# Altium schematic sheet Arduino-Pro-Mini.SchDoc(Arduino-Pro-Mini)
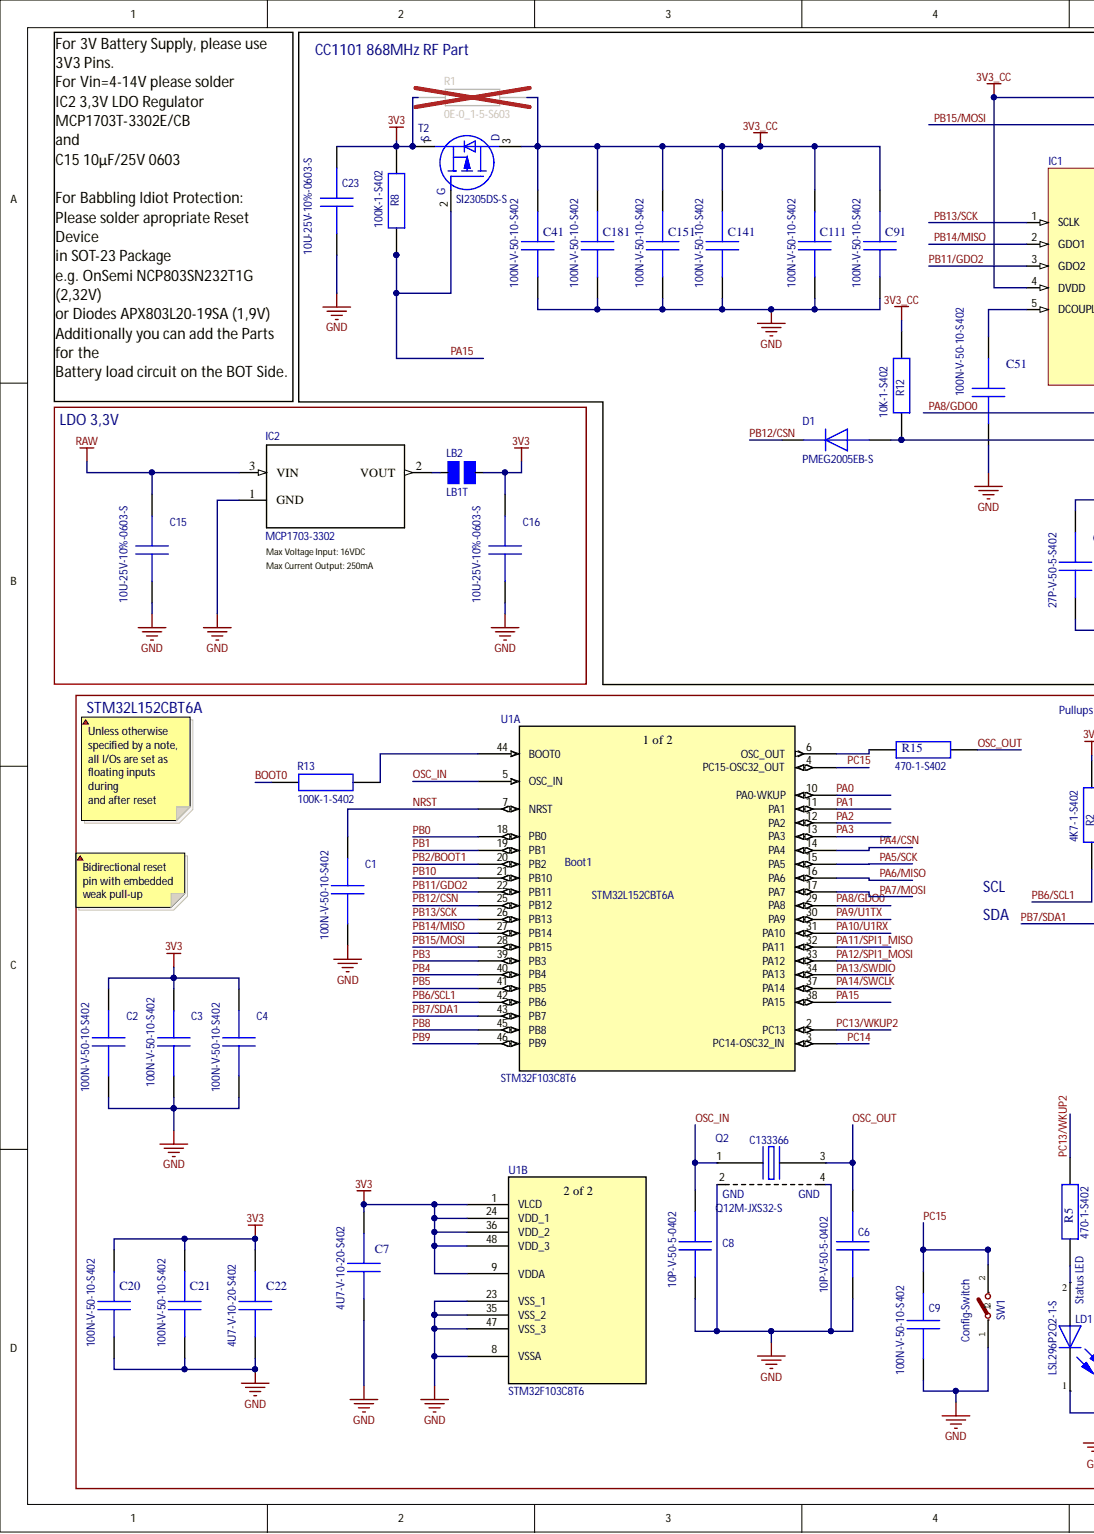
[diagram p0: Arduino-Pro-Mini.SchDoc, left]
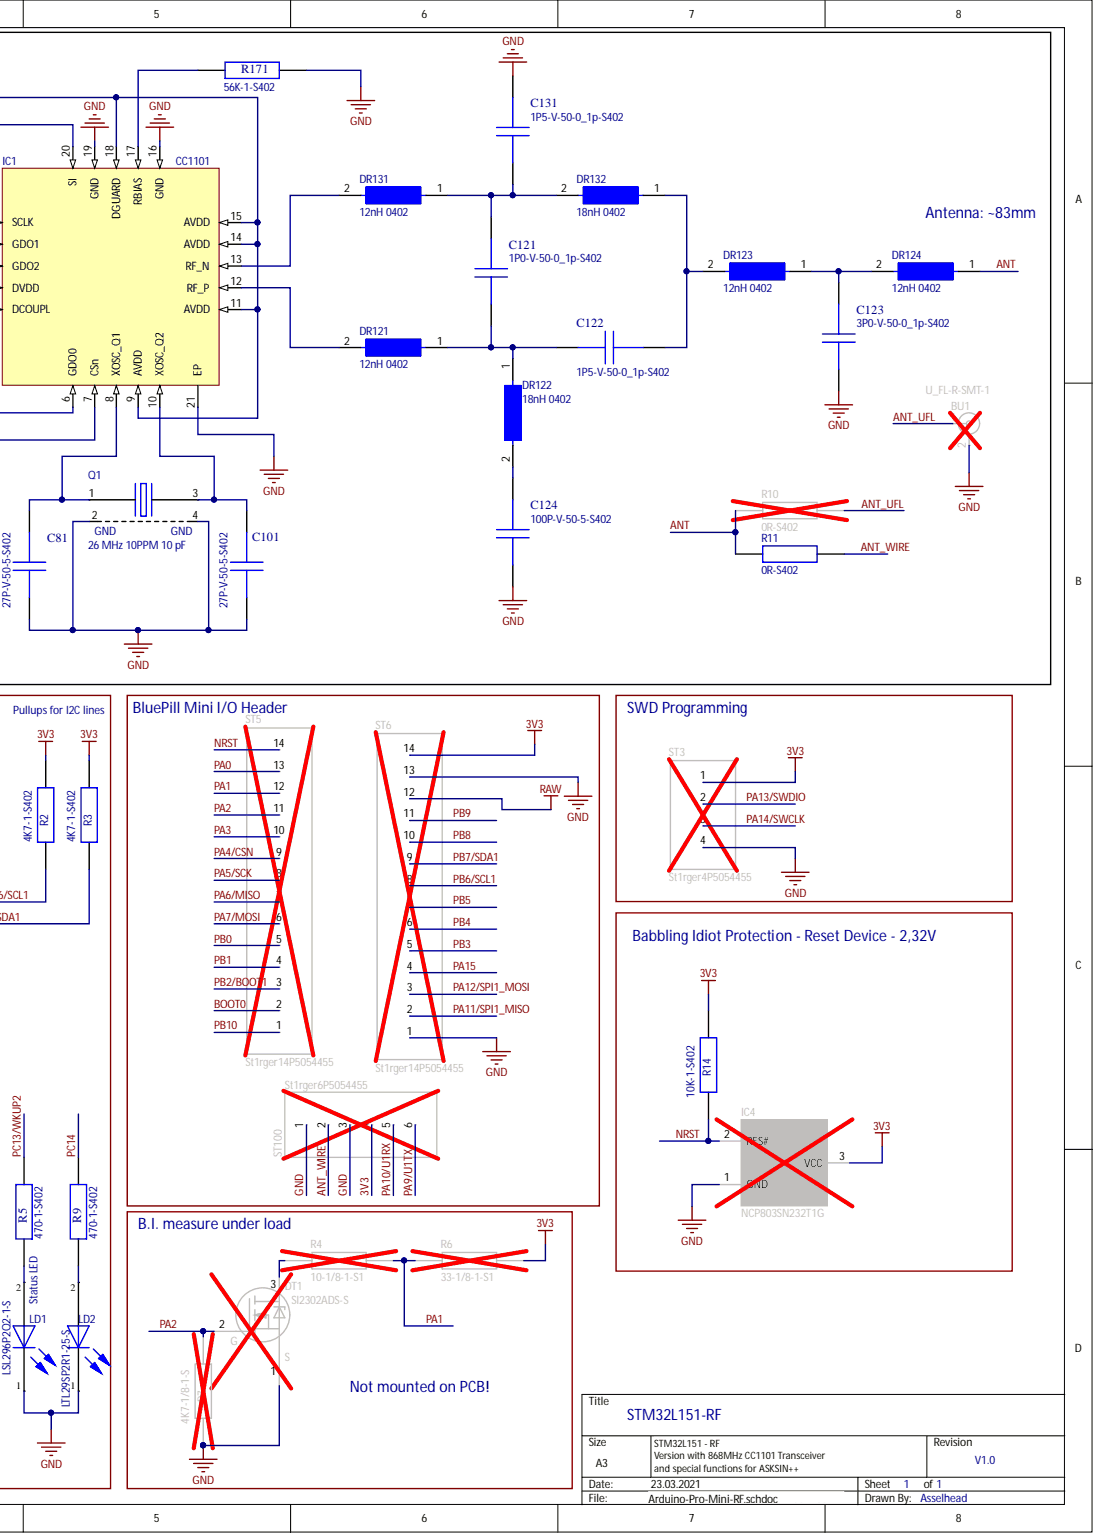
[diagram p1: Arduino-Pro-Mini.SchDoc, right]

## Parts
BU1  U_FL-R-SMT-1  [Foot_U_FL-R-SMT-1]
C1  100N-V-50-10-S402  [Foot 0402_Capacitor_Master]
C2  100N-V-50-10-S402  [Foot 0402_Capacitor_Master]
C3  100N-V-50-10-S402  [Foot 0402_Capacitor_Master]
C4  100N-V-50-10-S402  [Foot 0402_Capacitor_Master]
C6  10P-V-50-5-0402  [Foot 0402_Capacitor_Master]
C7  4U7-V-10-20-S402  [Foot 0402_Capacitor_Master]
C8  10P-V-50-5-0402  [Foot 0402_Capacitor_Master]
C9  100N-V-50-10-S402  [Foot 0402_Capacitor_Master]
C15  10U-25V-10%-0603-S  [Capacitor 0603_1.1mm]
C16  10U-25V-10%-0603-S  [Capacitor 0603_1.1mm]
C20  100N-V-50-10-S402  [Foot 0402_Capacitor_Master]
C21  100N-V-50-10-S402  [Foot 0402_Capacitor_Master]
C22  4U7-V-10-20-S402  [Foot 0402_Capacitor_Master]
C23  10U-25V-10%-0603-S  [Capacitor 0603_1.1mm]
C41  100N-V-50-10-S402  [Foot 0402_Capacitor_Master]
C51  100N-V-50-10-S402  [Foot 0402_Capacitor_Master]
C81  27P-V-50-5-S402  [Foot 0402_Capacitor_Master]
C91  100N-V-50-10-S402  [Foot 0402_Capacitor_Master]
C101  27P-V-50-5-S402  [Foot 0402_Capacitor_Master]
C111  100N-V-50-10-S402  [Foot 0402_Capacitor_Master]
C121  1P0-V-50-0_1p-S402  [Foot 0402_Capacitor_Master]
C122  1P5-V-50-0_1p-S402  [Foot 0402_Capacitor_Master]
C123  3P0-V-50-0_1p-S402  [Foot 0402_Capacitor_Master]
C124  100P-V-50-5-S402  [Foot 0402_Capacitor_Master]
C131  1P5-V-50-0_1p-S402  [Foot 0402_Capacitor_Master]
C141  100N-V-50-10-S402  [Foot 0402_Capacitor_Master]
C151  100N-V-50-10-S402  [Foot 0402_Capacitor_Master]
C181  100N-V-50-10-S402  [Foot 0402_Capacitor_Master]
D1  PMEG2005EB-S  [FootSOD523]
DR121  12nH 0402  Case/Package: 0402  [Foot0402R]
DR122  18nH 0402  Case/Package: 0402  [Foot0402R]
DR123  12nH 0402  Case/Package: 0402  [Foot0402R]
DR124  12nH 0402  Case/Package: 0402  [Foot0402R]
DR131  12nH 0402  Case/Package: 0402  [Foot0402R]
DR132  18nH 0402  Case/Package: 0402  [Foot0402R]
IC1  CC1101  [PCBComponent_1]
IC2  MCP1703-3302  [SOT23-3]
IC4  NCP803SN232T1G  [Foot555A]
LB2  LB1T  [Foot-LB-0603-2]  (no BOM)
LD1  LSL296P2Q2-1-S  [Foot-LED0603-Red]
LD2  LTL29SP2R1-25-S  [FootLED0603_1]
Q1  26 MHz 10PPM 10 pF  [FootFA238V]
Q2  Q12M-JXS32-S  [FootJXS32]
R1  0E-0_1-5-S603  [Foot_Resistor_0603]
R2  4K7-1-S402  [Foot 0402_Resistor_Master]
R3  4K7-1-S402  [Foot 0402_Resistor_Master]
R4  10-1/8-1-S1  [Foot4417A]
R5  470-1-S402  [Foot 0402_Resistor_Master]
R6  33-1/8-1-S1  [Foot4417A]
R7  4K7-1/8-1-S  [Foot4417A]
R8  100K-1-S402  [Foot 0402_Resistor_Master]
R9  470-1-S402  [Foot 0402_Resistor_Master]
R10  0R-S402  [Foot 0402_Resistor_Master]
R11  0R-S402  [Foot 0402_Resistor_Master]
R12  10K-1-S402  [Foot0402R]
R13  100K-1-S402  [Foot 0402_Resistor_Master]
R14  10K-1-S402  [Foot0402R]
R15  470-1-S402  [Foot 0402_Resistor_Master]
R171  56K-1-S402  [Foot 0402_Resistor_Master]
ST3  St1rger4P5054455  [Foot7331A]
ST5  St1rger14P5054455  [Foot5054455-14]
ST6  St1rger14P5054455  [Foot5054455-14]
ST100  St1rger6P5054455  [Foot7333A]
SW1  Tactile Switch SPST-NO Top Actuated Surface Mount  [OMRON_B3U-1000P_0]  (value not drawn)
T1  SI2302ADS-S  [Foot8309A]
T2  SI2305DS-S  [Foot8309A]
U1  STM32F103C8T6  [LQFP48-7x7mm]  (parts A,B)

## Nets
3V3_CC: C41.2 C91.2 C111.2 C141.2 C151.2 C181.2 IC1.4(DVDD) IC1.9(AVDD) IC1.11(AVDD) IC1.14(AVDD) IC1.15(AVDD) IC1.18(DGUARD) R1.2 R12.2 T2.3
RAW: C15.2 IC2.3 ST6.12
3V3: C2.1 C3.1 C4.1 C7.2 C16.2 C20.2 C21.2 C22.2 C23.2 IC4.3 LB2.2 R1.1 R2.1 R3.2 R6.2 R8.2 R14.2 ST3.1 ST6.14 ST100.4 T2.1 U1.1(VLCD) U1.9(VDDA) U1.24(VDD_1) U1.36(VDD_2) U1.48(VDD_3)
ANT: DR124.1 R10.1 R11.1
ANT_UFL: BU1.1 R10.2
ANT_WIRE: R11.2 ST100.2
BOOT0: R13.1 ST5.2
GND: BU1.2 C1.2 C2.2 C3.2 C4.2 C6.1 C7.1 C8.1 C9.2 C15.1 C16.1 C20.1 C21.1 C22.1 C23.1 C41.1 C51.1 C81.1 C91.1 C101.1 C111.1 C123.1 C124.1 C131.2 C141.1 C151.1 C181.1 IC1.16(GND) IC1.19(GND) IC1.21(EP) IC2.1 IC4.1 LD1.1 LD2.1 Q1.2 Q1.4 Q2.2 Q2.4 R7.1 R171.2 ST3.4 ST6.1 ST6.13 ST100.1 ST100.3 SW1.1 T1.1 U1.8(VSSA) U1.23(VSS_1) U1.35(VSS_2) U1.47(VSS_3)
NRST: C1.1 IC4.2 R14.1 ST5.14 U1.7(NRST)
OSC_IN: C8.2 Q2.1 U1.5(OSC_IN)
OSC_OUT: C6.2 Q2.3 R15.2
PA0: ST5.13 U1.10(PA0-WKUP)
PA1: R4.2 R6.1 ST5.12 U1.11(PA1)
PA2: R7.2 ST5.11 T1.2 U1.12(PA2)
PA3: ST5.10 U1.13(PA3)
PA4/CSN: ST5.9 U1.14(PA4)
PA5/SCK: ST5.8 U1.15(PA5)
PA6/MISO: ST5.7 U1.16(PA6)
PA7/MOSI: ST5.6 U1.17(PA7)
PA8/GDO0: IC1.6(GDO0) U1.29(PA8)
PA9/U1TX: ST100.6 U1.30(PA9)
PA10/U1RX: ST100.5 U1.31(PA10)
PA11/SPI1_MISO: ST6.2 U1.32(PA11)
PA12/SPI1_MOSI: ST6.3 U1.33(PA12)
PA13/SWDIO: ST3.2 U1.34(PA13)
PA14/SWCLK: ST3.3 U1.37(PA14)
PA15: R8.1 ST6.4 T2.2 U1.38(PA15)
PB0: ST5.5 U1.18(PB0)
PB1: ST5.4 U1.19(PB1)
PB2/BOOT1: ST5.3 U1.20(PB2)
PB3: ST6.5 U1.39(PB3)
PB4: ST6.6 U1.40(PB4)
PB5: ST6.7 U1.41(PB5)
PB6/SCL1: R2.2 ST6.8 U1.42(PB6)
PB7/SDA1: R3.1 ST6.9 U1.43(PB7)
PB8: ST6.10 U1.45(PB8)
PB9: ST6.11 U1.46(PB9)
PB10: ST5.1 U1.21(PB10)
PB11/GDO2: IC1.3(GDO2) U1.22(PB11)
PB12/CSN: D1.1 U1.25(PB12)
PB13/SCK: IC1.1(SCLK) U1.26(PB13)
PB14/MISO: IC1.2(GDO1) U1.27(PB14)
PB15/MOSI: IC1.20(SI) U1.28(PB15)
PC13/WKUP2: R5.2 U1.2(PC13)
PC14: R9.2 U1.3(PC14-OSC32_IN)
PC15: C9.1 SW1.2 U1.4(PC15-OSC32_OUT)
NetC51_2: C51.2 IC1.5(DCOUPL)
NetC81_2: C81.2 IC1.8(XOSC_Q1) Q1.1
NetC101_2: C101.2 IC1.10(XOSC_Q2) Q1.3
NetC121_1: C121.1 C122.1 DR121.1 DR122.1
NetC121_2: C121.2 C131.1 DR131.1 DR132.2
NetC122_2: C122.2 DR123.2 DR132.1
NetC123_2: C123.2 DR123.1 DR124.2
NetC124_2: C124.2 DR122.2
NetD1_2: D1.2 IC1.7(CSn) R12.1
NetDR121_2: DR121.2 IC1.12(RF_P)
NetDR131_2: DR131.2 IC1.13(RF_N)
NetIC1_17: IC1.17(RBIAS) R171.1
NetIC2_2: IC2.2 LB2.1
NetLD1_2: LD1.2 R5.1
NetLD2_2: LD2.2 R9.1
NetR4_1: R4.1 T1.3
NetR13_2: R13.2 U1.44(BOOT0)
NetR15_1: R15.1 U1.6(OSC_OUT)

## Library data of the parts
BU1 = CMP-CON-000898-3: Ultra Small Surface Mount Coaxial Connector- receptacle  U.FL-R-SMT-1; Voltage: 100V; Value: 50 ohms; TempRange: -40°C ~ +90°C; Symbol Name: BUCHS_2pol_ANT; Rows: 1; Pitch: 0; MountingTech: SMT; Frequency: 6GHz; ArticleNumber: 50651910; Type: PLUG STRAIGHT; Pins: 2; Library Name: SuB AVault; ComponentLink1URL: http://cad-plotsvr.ads.local/View/SuB_Zukauf_Datenblaetter/50651910.pdf; ComponentLink1Description: Datasheet; Component Kind: Standard
C1-C4 C9 C20 C21 C41 C51 C91 C111 C141 C151 C181 = CMP-CAP-000566-6: SMD KONDENSATOR KERAMIK-VIELSCHICHT 100NF 50V 10%; Type: CERAMIC; Symbol Name: CAP, unpol.; MountingTech: SMT; ArticleNumber: 50670440; Voltage: 50V; Value: 100nF; Tolerance: 10%; Pins: 2; neg# Tolerance: 10%; Library Name: SuB AVault; ComponentLink1URL: http://cad-plotsvr.ads.local/View/SuB_Zukauf_Datenblaetter/50670440.pdf; ComponentLink1Description: Datasheet; Component Kind: Standard; Case/Package: 0402
C6 C8 = CMP-CAP-000593-4: SMD KONDENSATOR KERAMIK-VIELSCHICHT 10PF 50V 5%; Type: CERAMIC; MountingTech: SMT; ArticleNumber: 50672970; Voltage: 50V; Value: 10pF; Tolerance: 5%; Pins: 2; Library Name: SuB AVault; ComponentLink1URL: http://cad-plotsvr.ads.local/View/SuB_Zukauf_Datenblaetter/50672970.pdf; ComponentLink1Description: Datasheet; Component Kind: Standard; Case/Package: 0402
C7 C22 = CMP-CAP-000598-2: SMD Capacitor Ceramic Multi-Layer 4,7UF 10V 20% Size 0402; Value: 4,7µF; TempRange: -55 to 85°C; MountingTech: SMT; ArticleNumber: 50674180; Voltage: 10V; Type: Ceramic; Tolerance: 20%; Pins: 2; Library Name: SuB AVault; ComponentLink1URL: http://cad-plotsvr.ads.local/Datasheet/50674180.pdf; ComponentLink1Description: Datasheet; Component Kind: Standard; Case/Package: 0402
C15 C16 C23 = CMP-CAP-000603-1: Multilayer Ceramic Capacitors MLCC - SMD/SMT 10UF 25V 10% 0603; Value: 10µF; Type: standard; Tolerance: 20%; TempRange: -55°C to 85°C; MountingTech: SMT; ArticleNumber: 50674910; Voltage: 25V; Pins: 2; Library Name: SuB AVault; ComponentLink1URL: http://cad-plotsvr.ads.local/Datasheet/50674910.pdf; ComponentLink1Description: Datasheet; Component Kind: Standard; Case/Package: 0603 inch
C81 C101 = CMP-CAP-000438-6: SMD KONDENSATOR KERAMIK-VIELSCHICHT 27PF 50V 5%; Type: CERAMIC; Symbol Name: CAP, unpol.; MountingTech: SMT; ArticleNumber: 50638410; Voltage: 50V; Value: 27pF; Tolerance: 5%; Pins: 2; neg# Tolerance: 5%; Library Name: SuB AVault; ComponentLink1URL: http://cad-plotsvr.ads.local/View/SuB_Zukauf_Datenblaetter/50638410.pdf; ComponentLink1Description: Datasheet; Component Kind: Standard; Case/Package: 0402
C121 = CMP-CAP-000455-6: SMD KONDENSATOR KERAMIK-VIELSCHICHT 1,0PF 50V; Value: 1pF; Type: CERAMIC; Tolerance: 10%; Symbol Name: CAP, unpol.; MountingTech: SMT; ArticleNumber: 50641490; Voltage: 50V; Pins: 2; neg# Tolerance: 10%; Library Name: SuB AVault; ComponentLink1URL: http://cad-plotsvr.ads.local/View/SuB_Zukauf_Datenblaetter/50641490.pdf; ComponentLink1Description: Datasheet; Component Kind: Standard; Case/Package: 0402
C122 C131 = CMP-CAP-000462-6: SMD KONDENSATOR KERAMIK-VIELSCHICHT 1,5PF 50V; Value: 1,5pF; Type: CERAMIC; Tolerance: 6,7%; Symbol Name: CAP, unpol.; MountingTech: SMT; ArticleNumber: 50641560; Voltage: 50V; Pins: 2; neg# Tolerance: 6,7%; Library Name: SuB AVault; ComponentLink1URL: http://cad-plotsvr.ads.local/View/SuB_Zukauf_Datenblaetter/50641560.pdf; ComponentLink1Description: Datasheet; Component Kind: Standard; Case/Package: 0402
C123 = CMP-CAP-000460-6: SMD KONDENSATOR KERAMIK-VIELSCHICHT 3,0PF 50V; Value: 3pF; Type: CERAMIC; Tolerance: 3,3%; Symbol Name: CAP, unpol.; MountingTech: SMT; ArticleNumber: 50641540; Voltage: 50V; Pins: 2; neg# Tolerance: 3,3%; Library Name: SuB AVault; ComponentLink1URL: http://cad-plotsvr.ads.local/View/SuB_Zukauf_Datenblaetter/50641540.pdf; ComponentLink1Description: Datasheet; Component Kind: Standard; Case/Package: 0402
C124 = CMP-CAP-000379-6: SMD KONDENSATOR KERAMIK-VIELSCHICHT 100PF 50V 5%; Type: CERAMIC; Symbol Name: CAP, unpol.; MountingTech: SMT; ArticleNumber: 50628950; Voltage: 50V; Value: 100pF; Tolerance: 5%; Pins: 2; neg# Tolerance: 5%; Library Name: SuB AVault; ComponentLink1URL: http://cad-plotsvr.ads.local/View/SuB_Zukauf_Datenblaetter/50628950.pdf; ComponentLink1Description: Datasheet; Component Kind: Standard; Case/Package: 0402
D1 = CMP-DIO-000161-2: DIODE PMEG2005EB-S (SMD); Vr: 20V; Vf: 430mV; Type: SCHOTTKY; TempRange: -65 to +125°C; MountingTech: SMT; If: 500mA; ArticleNumber: 50629030; Symbol Name: Diode; Pins: 2; Library Name: SuB AVault; ComponentLink1URL: http://cad-plotsvr.ads.local/View/SuB_Zukauf_Datenblaetter/50629030.pdf; ComponentLink1Description: Datasheet; Component Kind: Standard; Case/Package: SOD523
DR121-DR124 DR131 DR132 = CMP-IND-000138-5: DROSSEL 10 nH/100MHz (SMD); Value: 1,2nH; Tolerance: 5%; TempRange: -55°C - 125°C; Symbol Name: ENTSTOER-0206-S; MountingTech: SMT; DCR: 0,42; Current: 350mA; ArticleNumber: 50635650; Type: RFI; Pins: 2; Library Name: SuB AVault; ComponentLink1URL: http://cad-plotsvr.ads.local/View/SuB_Zukauf_Datenblaetter/50635650.pdf; ComponentLink1Description: Datasheet; Component Kind: Standard
IC1 = Component_1: Library Name: CC1101.SchLib; Component Kind: Standard
IC4 = CMP-IC-001339-2: UP RESET# MONITOR RES.TRES. 2,93V; Symbol Name: SPANN3-0685-S; Name: MAX809SEUR-S; MountingTech: SMT; ArticleNumber: 50604280; Type: VOLTAGE MONITORING; Library Name: SuB AVault; ComponentLink1URL: http://cad-plotsvr.ads.local/View/SuB_Zukauf_Datenblaetter/50604280.pdf; ComponentLink1Description: Datasheet; Component Kind: Standard
LB2 = CMP-GEN-000134-2: Solder Bridge; Value: 0; Pins: 0; Library Name: SuB AVault
LD1 = CMP-OPT-000140-4: LEUCHTDIODE ROT   (SMD TOP.); Value: Red; Type: STANDARD; Note: LED-rt-SMD; MountingTech: SMT; ArticleNumber: 50626870; Pins: 2; Library Name: SuB AVault; ComponentLink1URL: http://cad-plotsvr.ads.local/View/SuB_Zukauf_Datenblaetter/50626870.pdf; ComponentLink1Description: Datasheet; Component Kind: Standard; Color: Red; Case/Package: 0603
LD2 = CMP-OPT-000143-2: LEUCHTDIODE GRÜN   (SMD TOP.); Value: Green; Type: STANDARD; Symbol Name: LED; Note: LED-gn-SMD; MountingTech: SMT; ArticleNumber: 50626900; Pins: 2; Library Name: SuB AVault; ComponentLink1URL: http://cad-plotsvr.ads.local/View/SuB_Zukauf_Datenblaetter/50626900.pdf; ComponentLink1Description: Datasheet; Component Kind: Standard; Color: Green; Case/Package: 0603
Q1 = CMP-CLK-000103-4: SMD QUARZ 25,00MHz; Value: 25MHz; Type: QUARTZ; TempRange: -40°C - 85°C; Symbol Name: SMD-QUARZ1-3+GND; MountingTech: SMT; ArticleNumber: 50632550; Pins: 4; Library Name: SuB AVault; ComponentLink1URL: http://cad-plotsvr.ads.local/View/SuB_Zukauf_Datenblaetter/50632550.pdf; ComponentLink1Description: Datasheet; Component Kind: Standard; Case/Package: 3.2x2.5
Q2 = CMP-CLK-000121-3: SMD Quarz 12 MHz ; Jauch  12,0-JXS32-12-10/15-T1-LF; Value: 12MHz; Type: Crystal; Tolerance: 10; TempRange: -40 °C ~ + 85 °C; MountingTech: SMT; ArticleNumber: 50671930; Pins: 4; Library Name: SuB AVault; ComponentLink1URL: http://cad-plotsvr.ads.local/View/SuB_Zukauf_Datenblaetter/50671930.pdf; ComponentLink1Description: Datasheet; Component Kind: Standard; Case/Package: JXS32
R1 = CMP-RES-000620-5: WIDERSTAND 0E 0,1W 5% BAUF.0603; Value: 0; Symbol Name: Resistor; Power: 0,1; MountingTech: SMT; ArticleNumber: 50624860; Type: STANDARD; Tolerance: 5%; Pins: 2; neg# Tolerance: 5%; Library Name: SuB AVault; ComponentLink1URL: http://cad-plotsvr.ads.local/View/SuB_Zukauf_Datenblaetter/50624860.pdf; ComponentLink1Description: Datasheet; Component Kind: Standard; Case/Package: 0603
R2 R3 = CMP-RES-000668-7: WIDERSTAND 4K7 0,063W 1% BAUF.0402; Value: 4,7k; Symbol Name: Resistor; PowerRating: 63mW; Power: 0,063; MountingTech: SMT; ArticleNumber: 50628770; Type: STANDARD; Tolerance: 1%; Pins: 2; neg# Tolerance: 1%; Library Name: SuB AVault; ComponentLink1URL: http://cad-plotsvr.ads.local/View/SuB_Zukauf_Datenblaetter/50628770.pdf; ComponentLink1Description: Datasheet; Component Kind: Standard; Case/Package: 0402
R4 = CMP-RES-000423-3: WIDERSTAND 10R 1/8W 1% BAUF.805; Value: 10; Symbol Name: Resistor; Power: 1/8; MountingTech: SMT; ArticleNumber: 50602130; Type: STANDARD; Tolerance: 1%; Pins: 2; neg# Tolerance: 1%; Library Name: SuB AVault; ComponentLink1URL: http://cad-plotsvr.ads.local/View/SuB_Zukauf_Datenblaetter/50602130.pdf; ComponentLink1Description: Datasheet; Component Kind: Standard; Case/Package: 0805
R5 R9 R15 = CMP-RES-000725-7: WIDERSTAND 470R 0,063W 1% BAUF.0402; Value: 470; Symbol Name: Resistor; PowerRating: 63mW; Power: 0,063; MountingTech: SMT; ArticleNumber: 50639460; Type: STANDARD; Tolerance: 1%; Pins: 2; neg# Tolerance: 1%; Library Name: SuB AVault; ComponentLink1URL: http://cad-plotsvr.ads.local/View/SuB_Zukauf_Datenblaetter/50639460.pdf; ComponentLink1Description: Datasheet; Component Kind: Standard; Case/Package: 0402
R6 = CMP-RES-000424-3: WIDERSTAND 33R 1/8W 1% BAUF.805; Value: 33; Symbol Name: Resistor; Power: 1/8; MountingTech: SMT; ArticleNumber: 50602140; Type: STANDARD; Tolerance: 1%; Pins: 2; neg# Tolerance: 1%; Library Name: SuB AVault; ComponentLink1URL: http://cad-plotsvr.ads.local/View/SuB_Zukauf_Datenblaetter/50602140.pdf; ComponentLink1Description: Datasheet; Component Kind: Standard; Case/Package: 0805
R7 = CMP-RES-000466-3: WIDERSTAND 4K7 1/8W 1% BAUF.805; Value: 4,7k; Symbol Name: Resistor; Power: 1/8; MountingTech: SMT; ArticleNumber: 50604800; Type: STANDARD; Tolerance: 1%; Pins: 2; neg# Tolerance: 1%; Library Name: SuB AVault; ComponentLink1URL: http://cad-plotsvr.ads.local/View/SuB_Zukauf_Datenblaetter/50604800.pdf; ComponentLink1Description: Datasheet; Component Kind: Standard; Case/Package: 0805
R8 R13 = CMP-RES-000674-7: WIDERSTAND 100K 0,063W 1% BAUF.0402; Symbol Name: Resistor; PowerRating: 63mW; Power: 0,063; MountingTech: SMT; ArticleNumber: 50628830; Value: 100k; Type: STANDARD; Tolerance: 1%; Pins: 2; neg# Tolerance: 1%; Library Name: SuB AVault; ComponentLink1URL: http://cad-plotsvr.ads.local/View/SuB_Zukauf_Datenblaetter/50628830.pdf; ComponentLink1Description: Datasheet; Component Kind: Standard; Case/Package: 0402
R10 R11 = CMP-RES-000686-7: WIDERSTAND 0R BAUF.0402; Value: 0; Symbol Name: Resistor; MountingTech: SMT; ArticleNumber: 50630430; Type: STANDARD; Pins: 2; Library Name: SuB AVault; ComponentLink1URL: http://cad-plotsvr.ads.local/View/SuB_Zukauf_Datenblaetter/50630430.pdf; ComponentLink1Description: Datasheet; Component Kind: Standard; Case/Package: 0402
R12 R14 = CMP-RES-000689-7: WIDERSTAND 10K 0,063W 1% BAUF.0402; Symbol Name: Resistor; PowerRating: 63mW; Power: 0,063; MountingTech: SMT; ArticleNumber: 50630650; Value: 10k; Type: STANDARD; Tolerance: 1%; Pins: 2; neg# Tolerance: 1%; Library Name: SuB AVault; ComponentLink1URL: http://cad-plotsvr.ads.local/View/SuB_Zukauf_Datenblaetter/50630650.pdf; ComponentLink1Description: Datasheet; Component Kind: Standard; Case/Package: 0402
R171 = CMP-RES-000666-7: WIDERSTAND 56K 0,063W 1% BAUF.0402; Symbol Name: Resistor; PowerRating: 63mW; Power: 0,063; MountingTech: SMT; ArticleNumber: 50628750; Value: 56k; Type: STANDARD; Tolerance: 1%; Pins: 2; neg# Tolerance: 1%; Library Name: SuB AVault; ComponentLink1URL: http://cad-plotsvr.ads.local/View/SuB_Zukauf_Datenblaetter/50628750.pdf; ComponentLink1Description: Datasheet; Component Kind: Standard; Case/Package: 0402
ST3 = CMP-CON-000014-2: Header gerade 4 Pin von 5054455; Symbol Name: ST-4; Rows: 1; Pitch: 2,54; MountingTech: THT; ArticleNumber: 03268580; Type: PLUG STRAIGHT; Pins: 4; Note: St1rger4P5054455; Library Name: SuB AVault; ComponentLink1URL: http://cad-plotsvr.ads.local/View/SuB_Zukauf_Datenblaetter/03268580.pdf; ComponentLink1Description: Datasheet; Component Kind: Standard
ST5 ST6 = CMP-CON-000135-3: HEADER GERADE 14 POL. VON 5054455; Symbol Name: ST-14; Rows: 1; Pitch: 2,54; MountingTech: THT; ArticleNumber: 03498150; Type: PLUG STRAIGHT; Pins: 14; Note: St1rger14P5054455; Library Name: SuB AVault; ComponentLink1URL: http://cad-plotsvr.ads.local/View/SuB_Zukauf_Datenblaetter/03498150.pdf; ComponentLink1Description: Datasheet; Component Kind: Standard
ST100 = CMP-CON-000015-2: Header gerade 6 Pin von 5054455; Symbol Name: ST-6; Rows: 1; Pitch: 2,54; MountingTech: THT; ArticleNumber: 03268590; Type: PLUG STRAIGHT; Pins: 6; Note: St1rger6P5054455; Library Name: SuB AVault; ComponentLink1URL: http://cad-plotsvr.ads.local/View/SuB_Zukauf_Datenblaetter/03268590.pdf; ComponentLink1Description: Datasheet; Component Kind: Standard
SW1 = OMRON_B3U-1000POMRON_B3U-1000P_0_0: voltage_rating_DC: 12V; verification_version: 0.0.0.3; temperature_range_low: -25°C; temperature_range_high: +70°C; prefix: SW; operating_force: 1.5N; mfg_package_ident_rev: 0; MF: Omron; lead_free: Yes; GateName_1: G$0; electromechanical_life: 200000Cycles; device_class_L3: Tactile Switches; device_class_L2: Switches; device_class_L1: Electromechanical; current_rating: 0.05A; contact_resistance: 100mΩ; contact_current_rating: 50mA; centroid_not_specified: No; throw_configuration: SPST-NO; SymbolName_1: OMRON_B3U-1000P_0_0; rohs: Yes; Package: OMRON_B3U-1000P_0; package: SW_SMT_3MM2_2MM7; MPN: B3U-1000P; mouser_part_number: 653-B3U-1000P; mount: Surface Mount; mfg_package_ident_date: 0; mfg_package_ident: 0; Library Name: Omron - B3U-1000P.IntLib; height: 1.75mm; footprint_variant_name_0: Recommended_Land_Pattern; digikey_part_number: SW1020CT-ND; digikey_description: SWITCH TACTILE SPST-NO 0.05A 12V; DeviceSetName: OMRON_B3U-1000P; DeviceName: OMRON_B3U-1000P_0_0; datasheet: https://omronfs.omron.com/en_US/ecb/products/pdf/en-b3u.pdf; Component Kind: Standard; automotive: No; ambient_temperature_range_low: -25°C; ambient_temperature_range_high: +70°C; LibraryName: Upverter Library
T1 = CMP-TRS-000085-3: N-CHANNEL MOSFET Si2302ADS (SMD); VGS(th): 950mV; VGS: 4,5V; VDS: 20V; Type: FET N-CHANNEL; TempRange: -55°C - 150°C; Symbol Name: FET_N_1; RDSON: 85m; MountingTech: SMT; I-Drain: 2,4A; ArticleNumber: 50612780; Pins: 3; Library Name: SuB AVault; ComponentLink1URL: http://cad-plotsvr.ads.local/View/SuB_Zukauf_Datenblaetter/50612780.pdf; ComponentLink1Description: Datasheet; Component Kind: Standard; Case/Package: SOT23
T2 = CMP-TRS-000097-4: P - CHANNEL MOSFET; VGS(th): -450mV; VGS: -2,5V; VDS: -8V; Type: FET P-CHANNEL; TempRange: -55°C - 150°C; Symbol Name: FET_P_1; RDSON: 71m; MountingTech: SMT; I-Drain: -3A; CISS: 1,245nF; ArticleNumber: 50617200; Pins: 3; Library Name: SuB AVault; ComponentLink1URL: http://cad-plotsvr.ads.local/View/SuB_Zukauf_Datenblaetter/50617200.pdf; ComponentLink1Description: Datasheet; Component Kind: Standard; Case/Package: SOT23
U1 = STM32F103C8T6: No Description Available; Vendor: STMicroelectronics; SourceLibrary: Updates_to_database_2020-10-19; Built_By: EMA_UL_Team; Type: DEV; RefDes: RefDes; Manufacturer_Part_Number: STM32F103C8T6; Library Name: 2021-01-20_16-07-29.SchLib; Component Kind: Standard; Copyright: Copyright (C) 2018 Accelerated Designs. All rights reserved
IC2 = MCP1703-3302: Component Kind: Standard; Library Name: ASKSIN.SchLib
